# Altium schematic sheet ACCELEROMETERS.SchDoc
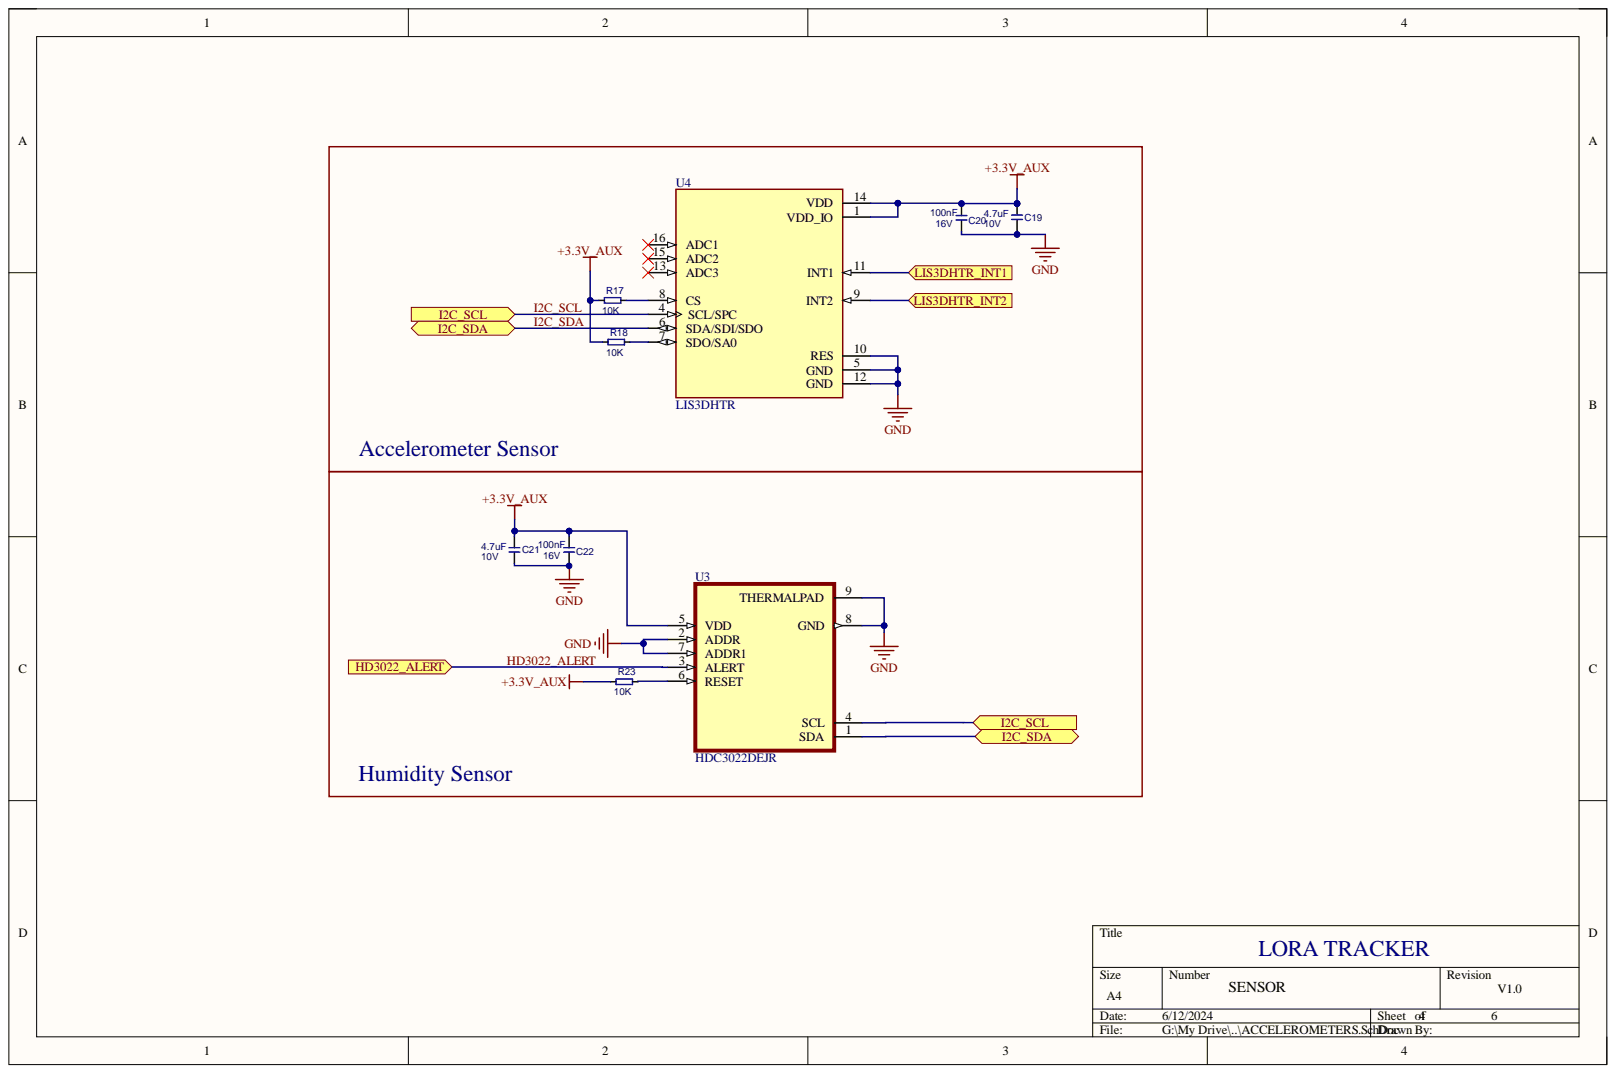
[diagram p0: ACCELEROMETERS.SchDoc]

## Parts
C19  4.7uF  [T_CAPC0603X33N]
C20  100nF  Rating: 16V  [CAPC1005X60N]
C21  4.7uF  Rating: 10V  [T_CAPC0603X33N]
C22  100nF  Rating: 16V  [CAPC1005X60N]
R17  10K  [RESC1005X40N]
R18  10K  [RESC1005X40N]
R23  10K  [RESC1005X40N]
U3  HDC3022DEJR  [SON50P200X200X80-9N]
U4  LIS3DHTR  [XDCR_LIS3DHTR]

## Ports
HD3022_ALERT, I2C_SCL, I2C_SDA, LIS3DHTR_INT1, LIS3DHTR_INT2

## Nets
+3.3V_AUX: C19.1 C20.1 C21.1 C22.1 R17.1 R18.1 R23.1 U3.5(VDD) U4.1(VDD_IO) U4.14(VDD)
GND: C19.2 C20.2 C21.2 C22.2 U3.2(ADDR) U3.7(ADDR1) U3.8(GND) U3.9(THERMALPAD) U4.5(GND) U4.10(RES) U4.12(GND)
HD3022_ALERT: U3.3(ALERT) ; port HD3022_ALERT
I2C_SCL: U3.4(SCL) U4.4(SCL/SPC) ; port I2C_SCL
I2C_SDA: U3.1(SDA) U4.6(SDA/SDI/SDO) ; port I2C_SDA
LIS3DHTR_INT1: U4.11(INT1) ; port LIS3DHTR_INT1
LIS3DHTR_INT2: U4.9(INT2) ; port LIS3DHTR_INT2
NetR17_2: R17.2 U4.8(CS)
NetR18_2: R18.2 U4.7(SDO/SA0)
NetR23_2: R23.2 U3.6(RESET)

Not connected: U4 13(ADC3) 15(ADC2) 16(ADC1)

## Library data of the parts
C19 C21 = C-X5R-4.7uF-10V-10%-0603: Capacitor Ceramic X5R 4.7uF 10V 10% 0603; Manufacturer Name: Generic; MP: CC0603KRX5R6BB475; Component Kind: Standard; Tolerance: 10%; Type: X5R; Value: 4.7uF; Library Name: Iris.SchLib
  C19: Rating: 10V
C20 C22 = C-X7R-100nF-16V-10%-0603: CAP CER 0.1UF 16V X7R 0402; MP: CC0402KRX7R7BB104; Tolerance: 10%; Component Kind: Standard; Type: X7R; Value: 100nF; Library Name: Evaluation Board.SchLib
R17 R18 R23 = R-22R-63mW-5%-0402: Resistor 10K ohm 63mW 5% 0402; MP: RC0402JR-0710KL; Component Kind: Standard; Rating: 63mW; Tolerance: 5%; Value: 10K; Library Name: Iris.SchLib
U3 = TLV620612TDSGRQ1: 0.5% RH DIGITAL HUMIDITY SENSOR; Availability: Unavailable; Component Kind: Standard; Library Name: HDC3022.SchLib; MP: HDC3022DEJR; Package: None; Price: None
U4 = LIS3DHTR: Accelerometer X, Y, Z Axis Â±2g, 4g, 8g, 16g 0.5Hz ~ 625Hz 16-LGA (3x3); MF: STMicroelectronics; Check_prices: https://www.snapeda.com/parts/LIS3DHTR/STMicroelectronics/view-part/278195/?ref=eda; Component Kind: Standard; Digi-Key_Part_Number: 497-10613-1-ND; Library Name: LIS3DHTR.IntLib; MP: LIS3DHTR; Package: LGA-16 STMicroelectronics; SnapEDA_Link: https://www.snapeda.com/parts/LIS3DHTR/STMicroelectronics/view-part/278195/?ref=snap
